# Altium schematic sheet Page6_Peripheral.SchDoc
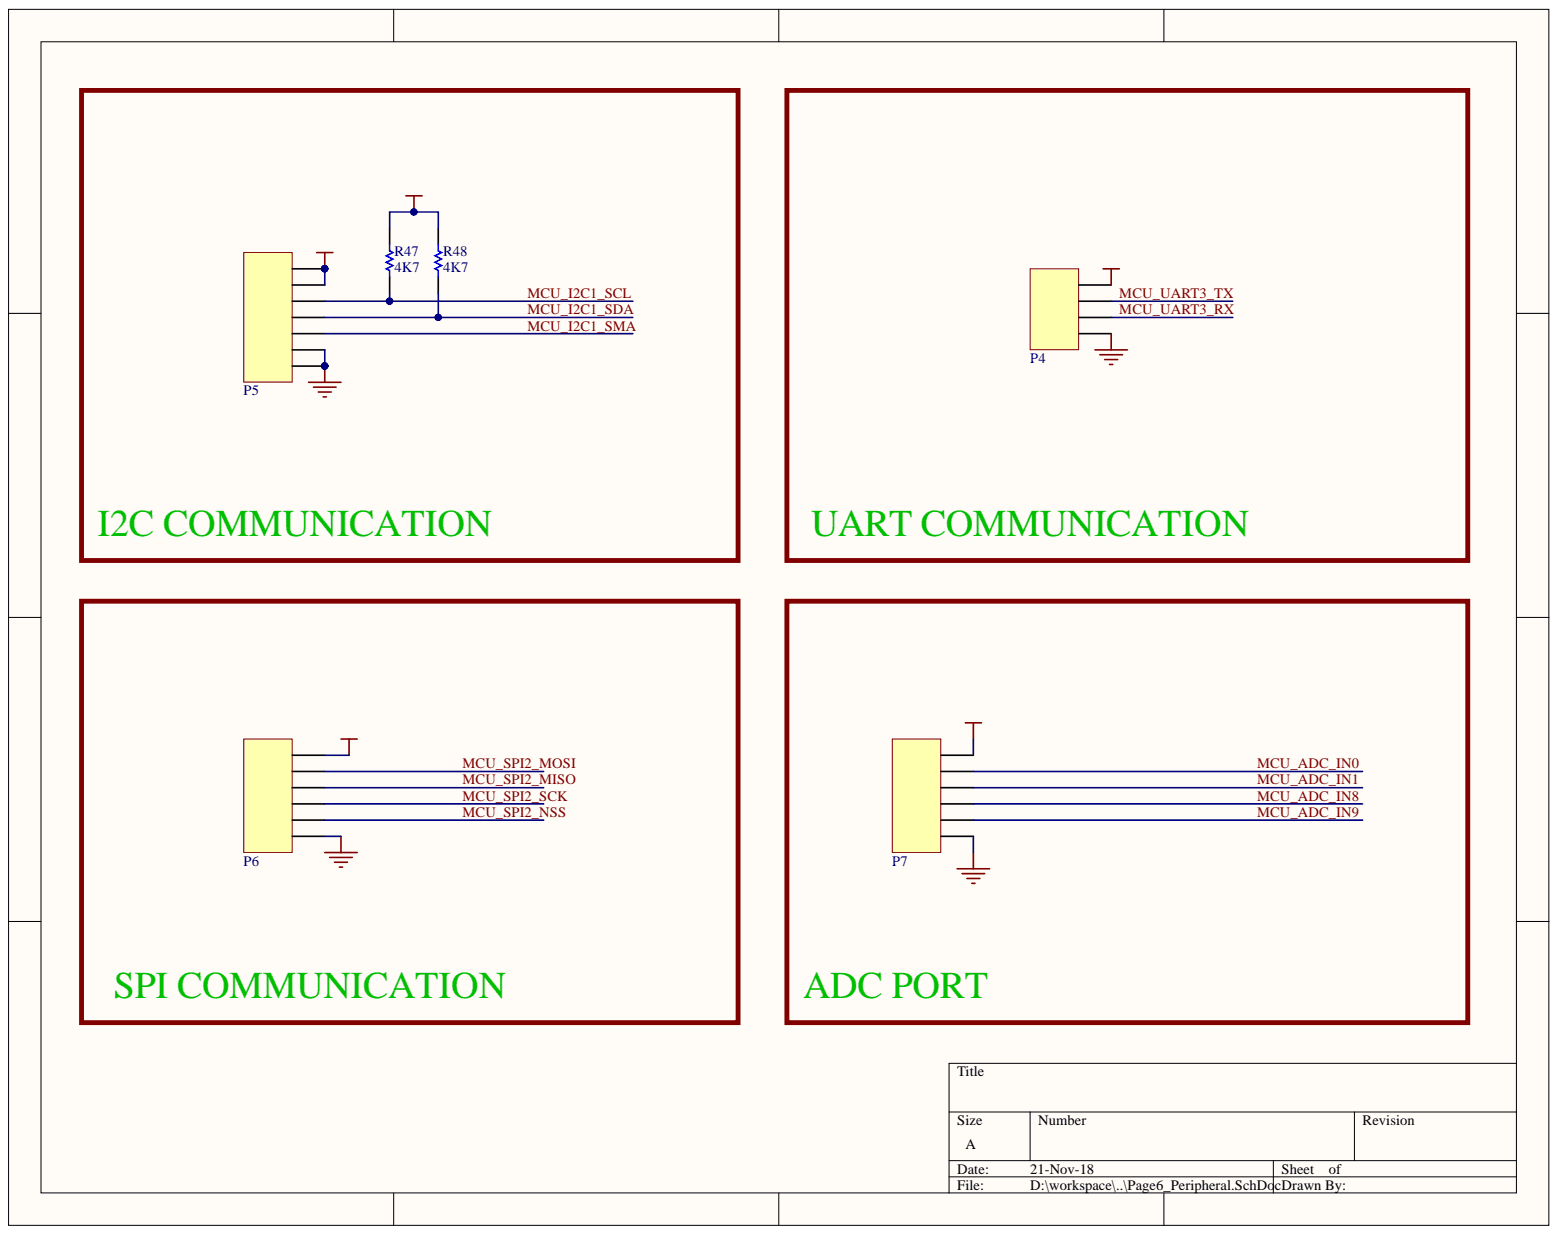
[diagram p0: Page6_Peripheral.SchDoc]

## Parts
P4  Header 4  [JP4]  (value not drawn)
P5  Header 7  [JP8]  (value not drawn)
P6  Header 6  [JP6]  (value not drawn)
P7  Header 6  [JP6]  (value not drawn)
R47  value 4K7  [RES-SM-0603]  (hidden comment: RESISTOR SMD)
R48  value 4K7  [RES-SM-0603]  (hidden comment: RESISTOR SMD)

## Nets
3V3: P4.4 P5.6 P5.7 P6.6 P7.6 R47.2 R48.2
GND: P4.1 P5.1 P5.2 P6.1 P7.1
MCU_ADC_IN0: P7.5
MCU_ADC_IN1: P7.4
MCU_ADC_IN8: P7.3
MCU_ADC_IN9: P7.2
MCU_I2C1_SCL: P5.5 R47.1
MCU_I2C1_SDA: P5.4 R48.1
MCU_I2C1_SMA: P5.3
MCU_SPI2_MISO: P6.4
MCU_SPI2_MOSI: P6.5
MCU_SPI2_NSS: P6.2
MCU_SPI2_SCK: P6.3
MCU_UART3_RX: P4.2
MCU_UART3_TX: P4.3

## Library data of the parts
P4 = Header 4: Header, 4-Pin; Component Kind: Standard; LatestRevisionDate: 17-Jul-2002; LatestRevisionNote: Re-released for DXP Platform.; Library Name: PIF_Library.IntLib; Publisher: Altium Limited
P5 = Header 7: Header, 7-Pin; Component Kind: Standard; LatestRevisionDate: 17-Jul-2002; LatestRevisionNote: Re-released for DXP Platform.; Library Name: PIF_Library.IntLib; Publisher: Altium Limited
P6 P7 = Header 6: Header, 6-Pin; Component Kind: Standard; LatestRevisionDate: 17-Jul-2002; LatestRevisionNote: Re-released for DXP Platform.; Library Name: PIF_Library.IntLib; Publisher: Altium Limited
R47 R48 = RESISTOR SMD: Resistor; Component Kind: Standard; Library Name: PIF_Library.IntLib
